# Altium schematic sheet Sheet4.SchDoc
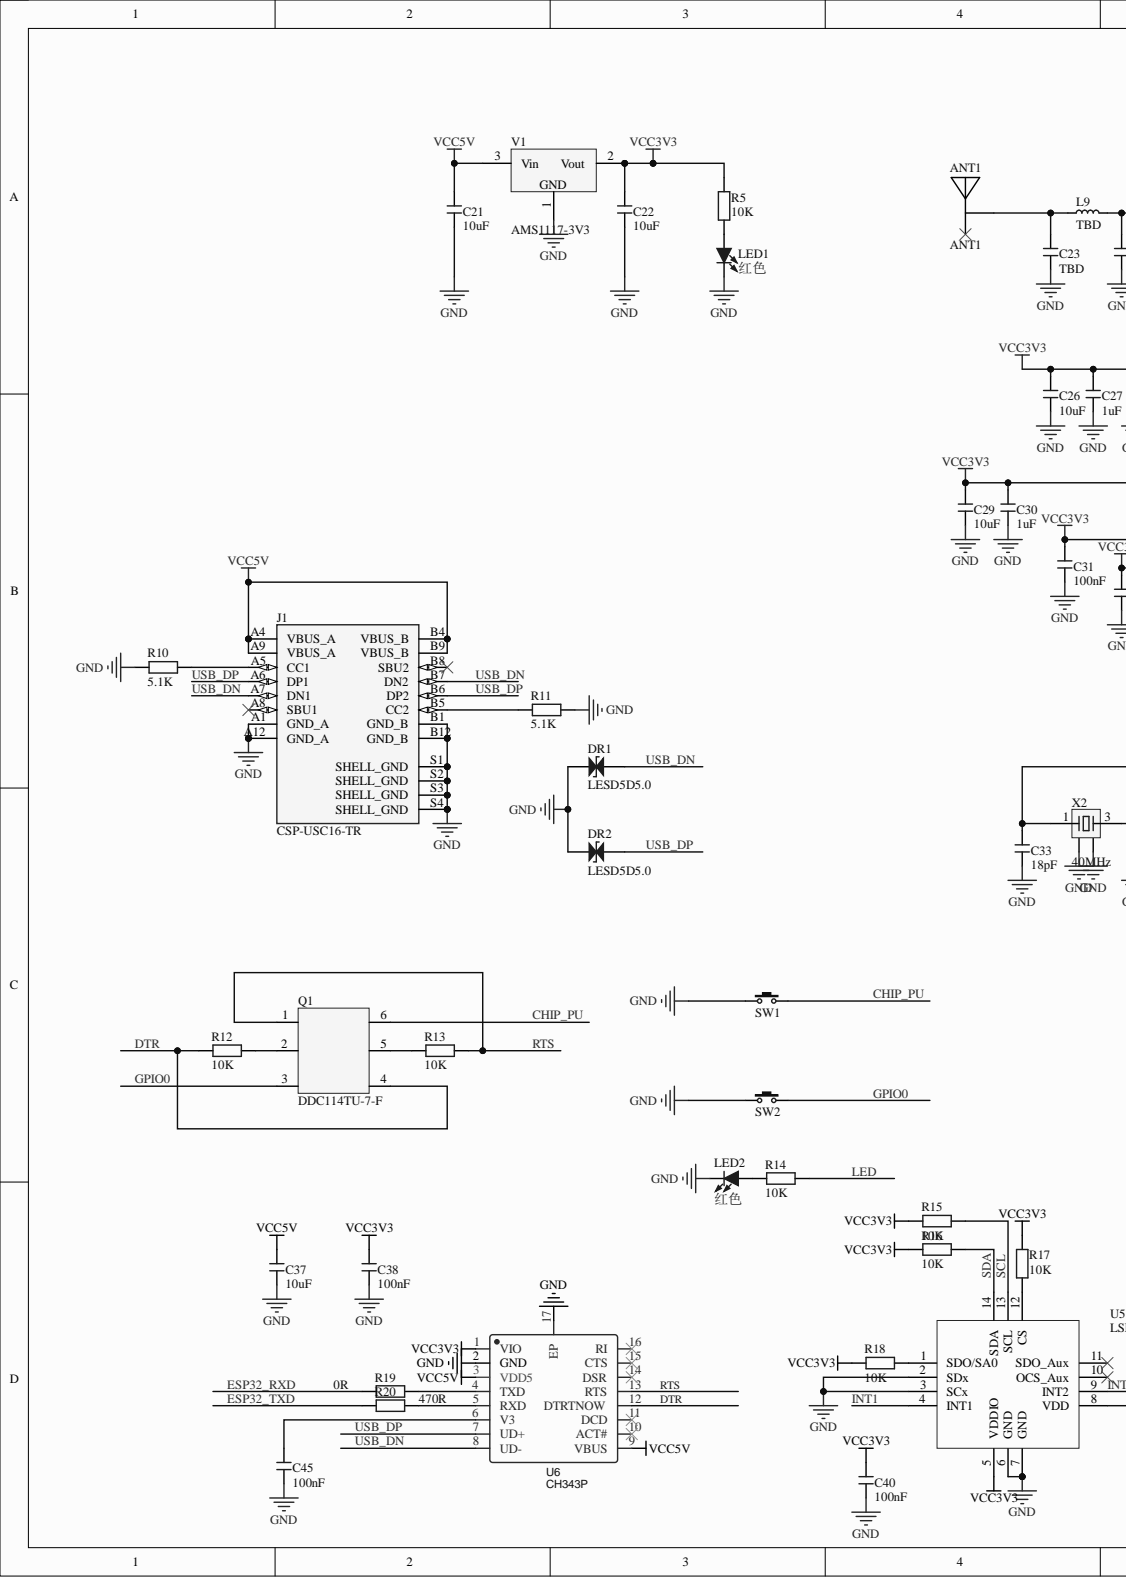
[diagram p0: Sheet4.SchDoc, left]
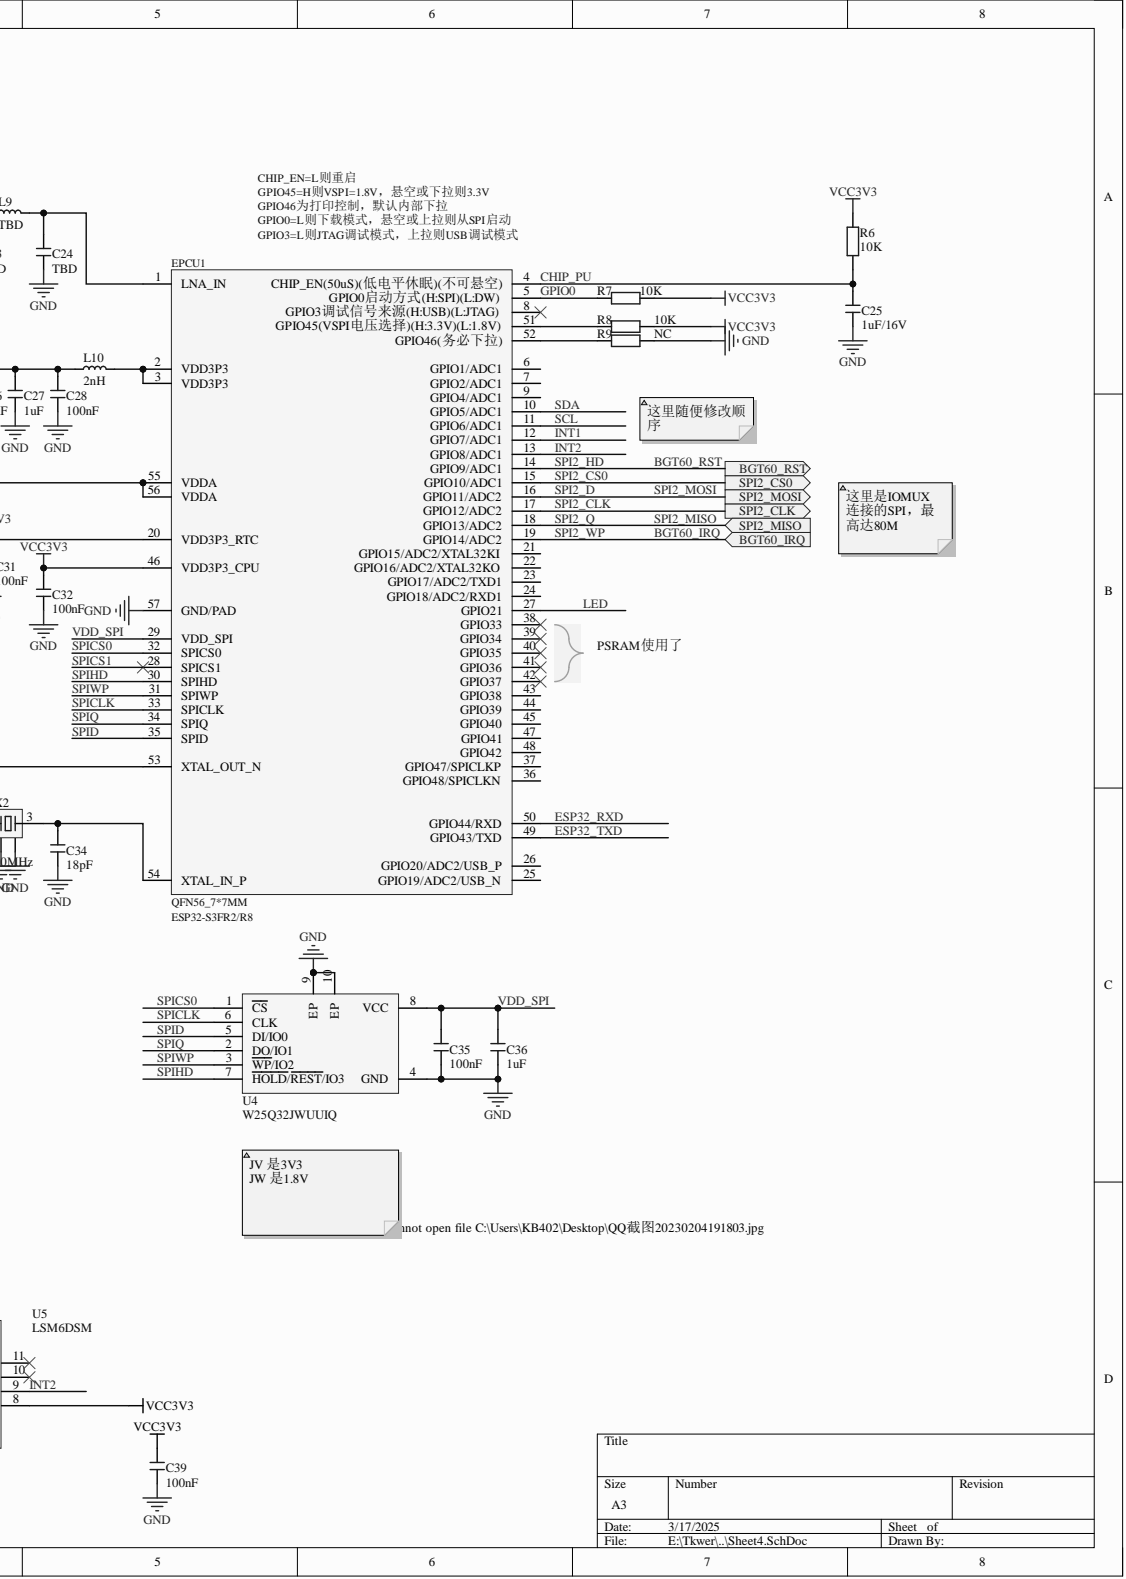
[diagram p1: Sheet4.SchDoc, right]

## Parts
ANT1  ANT1  [FILTER-SMD_1206-2P-L3.2-W1.6-L]
C21  10uF  [C 0603_L]
C22  10uF  [C 0603_L]
C23  TBD  [C 0402_L]
C24  TBD  [C 0402_L]
C25  1uF/16V  [C 0402_L]
C26  10uF  [C 0402_L]
C27  1uF  [C 0402_L]
C28  100nF  [C 0402_L]
C29  10uF  [C 0402_L]
C30  1uF  [C 0402_L]
C31  100nF  [C 0402_L]
C32  100nF  [C 0402_L]
C33  18pF  [C 0402_L]
C34  18pF  [C 0402_L]
C35  100nF  [C 0402_L]
C36  1uF  [C 0402_L]
C37  10uF  [C 0402_L]
C38  100nF  [C 0402_L]
C39  100nF  [C 0402_L]
C40  100nF  [C 0402_L]
C45  100nF  [C 0402_L]
DR1  LESD5D5.0  [SOD-523]
DR2  LESD5D5.0  [SOD-523]
EPCU1  ESP32-S3FR2/R8  [QFN-56_L7.0-W7.0-P0.40-TL-EP4.0]
J1  CSP-USC16-TR  [VALCON_CSP-USC16-TR]
L9  TBD  [L 0402]
L10  2nH  [L 0402]
LED1  红色  [LED-SMD]
LED2  红色  [LED-SMD]
Q1  DDC114TU-7-F  [SOT363]
R5  10K  [R 0402_L]
R6  10K  [R 0402_L]
R7  10K  [R 0402_L]
R8  10K  [R 0402_L]
R9  NC  [R 0402_L]
R10  5.1K  [R 0402_L]
R11  5.1K  [R 0402_L]
R12  10K  [R 0402_L]
R13  10K  [R 0402_L]
R14  10K  [R 0402_L]
R15  10K  [R 0402_L]
R16  10K  [R 0402_L]
R17  10K  [R 0402_L]
R18  10K  [R 0402_L]
R19  0R  [R 0402_L]
R20  470R  [R 0402_L]
SW1  3x6x5  [TSW 3*4*2-S-W]  (value not drawn)
SW2  3x6x5  [TSW 3*4*2-S-W]  (value not drawn)
U4  W25Q32JWUUIQ  [USON-8_L4.0-W3.0-P0.80-BL_GD25Q32CNIGR]
U5  LSM6DSM  [LGA-14_L3.0-W2.5-P0.50-TL]
U6  CH343P  [21-0136I_T1633F-3]
V1  AMS1117-3V3  [SOT89_L]
X2  40MHz  [OSC 2025-4P]

## Ports
BGT60_IRQ, BGT60_RST, SPI2_CLK, SPI2_CS0, SPI2_MISO, SPI2_MOSI

## Nets
GND: C21.1 C22.1 C23.1 C24.1 C25.1 C26.1 C27.1 C28.1 C29.1 C30.1 C31.1 C32.1 C33.1 C34.1 C35.1 C36.1 C37.1 C38.1 C39.1 C40.1 C45.1 DR1.2 DR2.2 EPCU1.57(GND/PAD) J1.A1(GND_A) J1.A12(GND_A) J1.B1(GND_B) J1.B12(GND_B) J1.S1(SHELL_GND) J1.S2(SHELL_GND) J1.S3(SHELL_GND) J1.S4(SHELL_GND) LED1.2 LED2.2 R9.2 R10.2 R11.1 SW1.1 SW2.1 U4.4(GND) U4.9(EP) U4.10(EP) U5.2(SDx) U5.3(SCx) U5.6(GND) U5.7(GND) U6.2(GND) U6.17(EP) V1.1 X2.2 X2.4
VCC3V3: C22.2 C26.2 C27.2 C28.2 C29.2 C30.2 C31.2 C32.2 C38.2 C39.2 C40.2 EPCU1.20(VDD3P3_RTC) EPCU1.46(VDD3P3_CPU) EPCU1.55(VDDA) EPCU1.56(VDDA) L10.1 R5.2 R6.1 R7.2 R8.2 R15.2 R16.2 R17.2 R18.2 U5.5(VDDIO) U5.8(VDD) U6.1(VIO) V1.2
VCC5V: C21.2 C37.2 J1.A4(VBUS_A) J1.A9(VBUS_A) J1.B4(VBUS_B) J1.B9(VBUS_B) U6.3(VDD5) U6.9(VBUS) V1.3
BGT60_IRQ: EPCU1.19(GPIO14/ADC2) ; port BGT60_IRQ
BGT60_RST: EPCU1.14(GPIO9/ADC1) ; port BGT60_RST
CHIP_PU: C25.2 EPCU1.4()) Q1.6 R6.2 SW1.2
DTR: Q1.4 R12.2 U6.12(DTRTNOW)
ESP32_RXD: EPCU1.50(GPIO44/RXD) R19.2
ESP32_TXD: EPCU1.49(GPIO43/TXD) R20.2
GPIO0: EPCU1.5((H:SPI)(L:DW)) Q1.3 R7.1 SW2.2
INT1: EPCU1.12(GPIO7/ADC1) U5.4(INT1)
INT2: EPCU1.13(GPIO8/ADC1) U5.9(INT2)
LED: EPCU1.27(GPIO21) R14.2
RTS: Q1.1 R13.1 U6.13(RTS)
SCL: EPCU1.11(GPIO6/ADC1) R15.1 U5.13(SCL)
SDA: EPCU1.10(GPIO5/ADC1) R16.1 U5.14(SDA)
SPI2_CLK: EPCU1.17(GPIO12/ADC2) ; port SPI2_CLK
SPI2_CS0: EPCU1.15(GPIO10/ADC1) ; port SPI2_CS0
SPI2_D: EPCU1.16(GPIO11/ADC2) ; port SPI2_MOSI
SPI2_MISO: EPCU1.18(GPIO13/ADC2) ; port SPI2_MISO
SPICLK: EPCU1.33(SPICLK) U4.6(CLK)
SPICS0: EPCU1.32(SPICS0) U4.1(CS)
SPICS1: EPCU1.28(SPICS1)
SPID: EPCU1.35(SPID) U4.5(DI/IO0)
SPIHD: EPCU1.30(SPIHD) U4.7(HOLD/REST/IO3)
SPIQ: EPCU1.34(SPIQ) U4.2(DO/IO1)
SPIWP: EPCU1.31(SPIWP) U4.3(WP/IO2)
USB_DN: DR1.1 J1.A7(DN1) J1.B7(DN2) U6.8(UD-)
USB_DP: DR2.1 J1.A6(DP1) J1.B6(DP2) U6.7(UD+)
VDD_SPI: C35.2 C36.2 EPCU1.29(VDD_SPI) U4.8(VCC)
NetANT1_1: ANT1.1 C23.2 L9.1
NetC24_2: C24.2 EPCU1.1(LNA_IN) L9.2
NetC33_2: C33.2 EPCU1.53(XTAL_OUT_N) X2.1
NetC34_2: C34.2 EPCU1.54(XTAL_IN_P) X2.3
NetC45_2: C45.2 U6.6(V3)
NetEPCU1_2: EPCU1.2(VDD3P3) EPCU1.3(VDD3P3) L10.2
NetEPCU1_51: EPCU1.51()(H:3.3V)(L:1.8V)) R8.1
NetEPCU1_52: EPCU1.52()) R9.1
NetJ1_A5: J1.A5(CC1) R10.1
NetJ1_B5: J1.B5(CC2) R11.2
NetLED1_1: LED1.1 R5.1
NetLED2_1: LED2.1 R14.1
NetQ1_2: Q1.2 R12.1
NetQ1_5: Q1.5 R13.2
NetR17_1: R17.1 U5.12(CS)
NetR18_1: R18.1 U5.1(SDO/SA0)
NetR19_1: R19.1 U6.4(TXD)
NetR20_1: R20.1 U6.5(RXD)

Not connected: ANT1 2; EPCU1 6(GPIO1/ADC1) 7(GPIO2/ADC1) 8((H:USB)(L:JTAG)) 9(GPIO4/ADC1) 21(GPIO15/ADC2/XTAL32KI) 22(GPIO16/ADC2/XTAL32KO) 23(GPIO17/ADC2/TXD1) 24(GPIO18/ADC2/RXD1) 25(GPIO19/ADC2/USB_N) 26(GPIO20/ADC2/USB_P) 36(GPIO48/SPICLKN) 37(GPIO47/SPICLKP) 38(GPIO33) 39(GPIO34) 40(GPIO35) 41(GPIO36) 42(GPIO37) 43(GPIO38) 44(GPIO39) 45(GPIO40) 47(GPIO41) 48(GPIO42); J1 A8(SBU1) B8(SBU2); U5 10(OCS_Aux) 11(SDO_Aux); U6 10(ACT#) 11(DCD) 14(DSR) 15(CTS) 16(RI)

## Library data of the parts
ANT1 = ANT: 陶瓷天线; BOM名称: PCB板载天线; 版权归属: 源创客; Component Kind: Standard; Library Name: 14.1 - 天线.SchLib; 学习资源: www.ooocke.com
C21-C40 C45 = C: 无极性贴片电容; 版权归属: 源创客; BOM名称: 无极性贴片电容; Component Kind: Standard; Library Name: 3.1   - 电阻-电容-电感.SchLib; 学习资源: www.ooocke.com
DR1 DR2 = DR: 瞬态电压抑制二极管; 版权归属: 源创客; BOM名称: 瞬态电压抑制二极管; Component Kind: Standard; Library Name: 8.1   - 二极管-整流桥.SchLib; 学习资源: www.ooocke.com
J1 = CSP-USC16-TR: CSP-USC16-TR Valcon USB Type C Surface Mount PCB Socket; Availability: No availability data; Check_prices: https://www.snapeda.com/parts/CSP-USC16-TR/Valcon/view-part/4783629/?ref=eda; Component Kind: Standard; Component Type: Source; Library Name: CSP-USC16-TR.IntLib; MF: Valcon; MP: CSP-USC16-TR; Package: None; Price: None; Purchase-URL: https://pricing.snapeda.com/search/part/CSP-USC16-TR/?ref=eda; SnapEDA_Link: https://www.snapeda.com/parts/CSP-USC16-TR/Valcon/view-part/4783629/?ref=snap
L9 L10 = L: 小功率贴片电感; 版权归属: 源创客; BOM名称: 小功率贴片电感; Component Kind: Standard; Library Name: 3.1   - 电阻-电容-电感.SchLib; 学习资源: www.ooocke.com
LED1 LED2 = LED-SMD: 贴片LED; 版权归属: 源创客; BOM名称: 贴片LED; Component Kind: Standard; Library Name: 9.1   - LED.SchLib; 学习资源: www.ooocke.com
R5-R20 = R: 贴片电阻; 版权归属: 源创客; BOM名称: 贴片电阻; Component Kind: Standard; Library Name: 3.1   - 电阻-电容-电感.SchLib; 学习资源: www.ooocke.com
SW1 SW2 = TSW 3x6: 3x6轻触开关; 版权归属: 源创客; BOM名称: 3x6轻触开关; Component Kind: Standard; Library Name: 5.1   - 按键-开关.SchLib; 学习资源: www.ooocke.com
U5 = LSM6DSM: LSM6DSM 六轴传感器，牛皮; Component Kind: Standard; Library Name: 1.1   - IC芯片.SchLib
U6 = CH343P: BOM_Manufacturer: WCH(南京沁恒); Contributor: LCSC; BOM_JLCPCB Part Class: Extended Part; BOM_Manufacturer Part: CH343P; BOM_Supplier: LCSC; BOM_Supplier Part: C2846043; Component Kind: Standard; spicePre: U; spiceSymbolName: CH343P
V1 = AMS1117: 三端稳压芯片; BOM名称: 稳压芯片; 版权归属: 源创客; Component Kind: Standard; Library Name: 1.3   - 电源类芯片.SchLib; 学习资源: www.ooocke.com
X2 = XTAL-4P: 4脚无源晶振; 版权归属: 源创客; BOM名称: 4脚无源晶振; Component Kind: Standard; Library Name: 10.1 - 保险丝-晶振.SchLib; 学习资源: www.ooocke.com
EPCU1 = ESP32-S3FR2/R8: Component Kind: Standard
Q1 = DDC114TU-7-F: Component Kind: Standard; Library Name: 6.2   - 三极管.SchLib
U4 = W25Q32JWUUIQ: Component Kind: Standard; Library Name: 1.1   - IC芯片.SchLib
